# Altium schematic sheet MIXR Input System - Power&Control.SchDoc
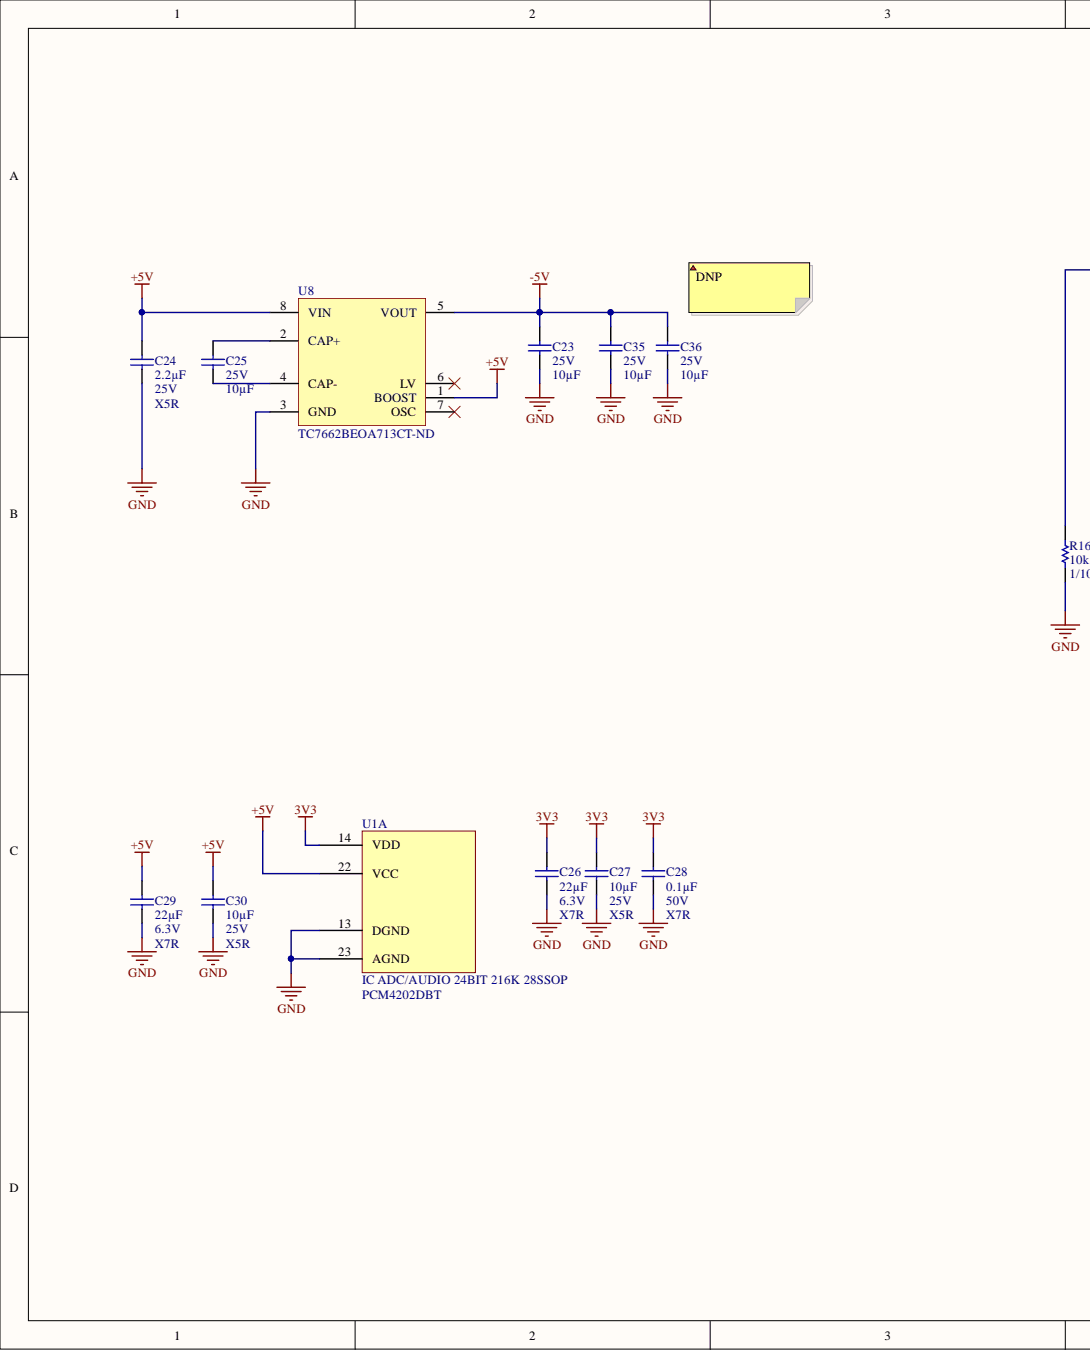
[diagram p0: MIXR Input System - Power&Control.SchDoc, left]
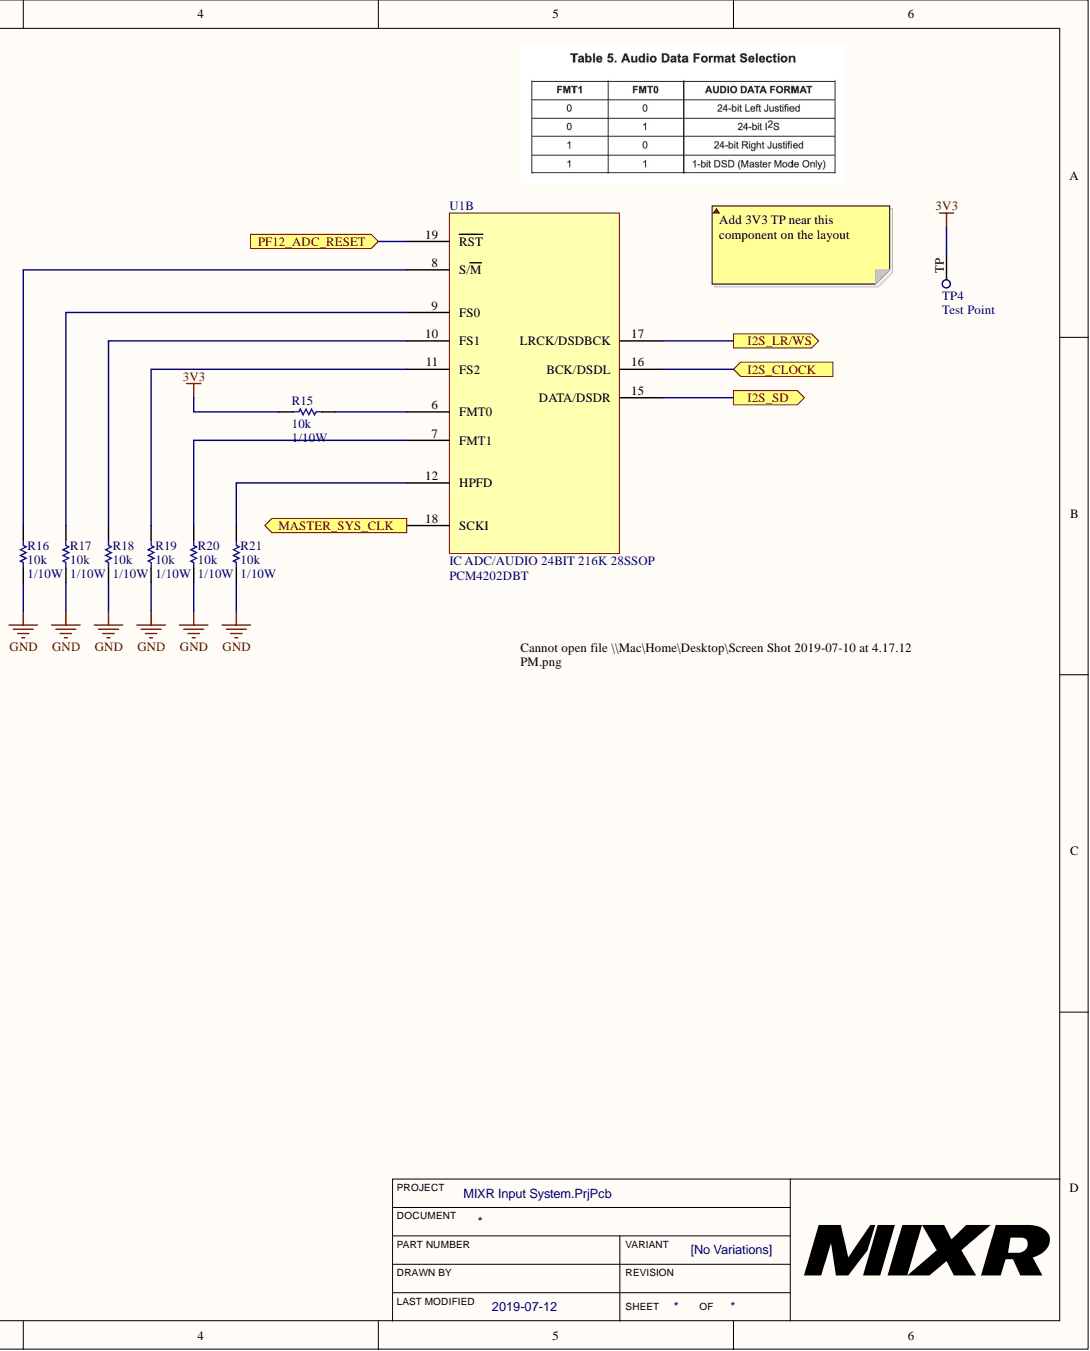
[diagram p1: MIXR Input System - Power&Control.SchDoc, right]

## Parts
C23  Capacitance: 10µF  Voltage - Rated: 25V  [CAP, 0805]  (hidden comment: CAP CER 10uF 25V 10% X5R 0805)
C24  Capacitance: 2.2µF  Temperature Coefficient: X5R  Voltage: 25V  [CAP, 0603]  (hidden comment: CAP CER 2.2UF 25V 10% X5R 0603)
C25  Capacitance: 10µF  Voltage - Rated: 25V  [CAP, 0805]  (hidden comment: CAP CER 10uF 25V 10% X5R 0805)
C26  Capacitance: 22µF  Temperature Coefficient: X7R  Voltage: 6.3V  [CAP, 1206]  (hidden comment: CAP CER 22UF 6.3V ±10% X7R 1206)
C27  Capacitance: 10µF  Temperature Coefficient: X5R  Voltage: 25V  [CAP, 0603]  (hidden comment: CAP CER 10uF 25V 20% X5R 0603)
C28  Capacitance: 0.1µF  Temperature Coefficient: X7R  Voltage: 50V  [CAP, 0603]  (hidden comment: CAP CER 0.1UF 50V 10% X7R 0603)
C29  Capacitance: 22µF  Temperature Coefficient: X7R  Voltage: 6.3V  [CAP, 1206]  (hidden comment: CAP CER 22UF 6.3V ±10% X7R 1206)
C30  Capacitance: 10µF  Temperature Coefficient: X5R  Voltage: 25V  [CAP, 0603]  (hidden comment: CAP CER 10uF 25V 20% X5R 0603)
C35  Capacitance: 10µF  Voltage - Rated: 25V  [CAP, 0805]  (hidden comment: CAP CER 10uF 25V 10% X5R 0805)
C36  Capacitance: 10µF  Voltage - Rated: 25V  [CAP, 0805]  (hidden comment: CAP CER 10uF 25V 10% X5R 0805)
R15  Power: 1/10W  Resistance: 10k  [RES, 0603]  (hidden comment: RES 10K OHM 1% 1/10W 0603)
R16  Power: 1/10W  Resistance: 10k  [RES, 0603]  (hidden comment: RES 10K OHM 1% 1/10W 0603)
R17  Power: 1/10W  Resistance: 10k  [RES, 0603]  (hidden comment: RES 10K OHM 1% 1/10W 0603)
R18  Power: 1/10W  Resistance: 10k  [RES, 0603]  (hidden comment: RES 10K OHM 1% 1/10W 0603)
R19  Power: 1/10W  Resistance: 10k  [RES, 0603]  (hidden comment: RES 10K OHM 1% 1/10W 0603)
R20  Power: 1/10W  Resistance: 10k  [RES, 0603]  (hidden comment: RES 10K OHM 1% 1/10W 0603)
R21  Power: 1/10W  Resistance: 10k  [RES, 0603]  (hidden comment: RES 10K OHM 1% 1/10W 0603)
TP4  Test Point  [Test Point]  (no BOM)
U1  IC ADC/AUDIO 24BIT 216K 28SSOP  Manufacturer Part Number: PCM4202DBT  [IC ADC 24 BIT AUDIO 216 KHZ SSOP-28]  (parts A,B)
U8  Supplier Part Number 1: TC7662BEOA713CT-ND  [SOIC8]  (hidden comment: IC REG SWTCHD CAP INV 20MA 8SOIC)

## Ports
I2S_CLOCK, I2S_LR/WS, I2S_SD, MASTER_SYS_CLK, PF12_ADC_RESET

## Nets
3V3: C26.1 C27.1 C28.1 R15.1 TP4.TP U1.14(VDD)
+5V: C24.2 C29.1 C30.1 U1.22(VCC) U8.1(BOOST) U8.8(VIN)
-5V: C23.1 C35.1 C36.1 U8.5(VOUT)
GND: C23.2 C24.1 C26.2 C27.2 C28.2 C29.2 C30.2 C35.2 C36.2 R16.2 R17.2 R18.2 R19.2 R20.2 R21.2 U1.13(DGND) U1.23(AGND) U8.3(GND)
I2S_CLOCK: U1.16(BCK/DSDL) ; port I2S_CLOCK
I2S_LR/WS: U1.17(LRCK/DSDBCK) ; port I2S_LR/WS
I2S_SD: U1.15(DATA/DSDR) ; port I2S_SD
MASTER_SYS_CLK: U1.18(SCKI) ; port MASTER_SYS_CLK
PF12_ADC_RESET: U1.19(RST) ; port PF12_ADC_RESET
NetC25_1: C25.1 U8.2(CAP+)
NetC25_2: C25.2 U8.4(CAP-)
NetR15_2: R15.2 U1.6(FMT0)
NetR16_1: R16.1 U1.8(S/M)
NetR17_1: R17.1 U1.9(FS0)
NetR18_1: R18.1 U1.10(FS1)
NetR19_1: R19.1 U1.11(FS2)
NetR20_1: R20.1 U1.7(FMT1)
NetR21_1: R21.1 U1.12(HPFD)

Not connected: U8 6(LV) 7(OSC)

## Library data of the parts
C23 C25 C35 C36 = CAP CER 10uF 25V 10% X5R 0805: 10µF ±10% 25V Ceramic Capacitor X5R 0805 (2012 Metric); Manufacturer: Murata Electronics North America; Manufacturer Part Number: GRM21BR61E106KA73L; Applications: General Purpose; Category: Capacitors; Component Kind: Standard; ComponentLink1Description: http://search.murata.co.jp/Ceramy/image/img/A01X/G101/ENG/GRM21BR61E106KA73-01.pdf; ComponentLink1URL: http://search.murata.co.jp/Ceramy/image/img/A01X/G101/ENG/GRM21BR61E106KA73-01.pdf; Features: -; Height - Seated (Max): -; Lead Spacing: -; Lead Style: -; Mounting Type: Surface Mount, MLCC; Operating Temperature: -55°C ~ 85°C; Package / Case: 0805 (2012 Metric); Packaging: Cut Tape (CT); Part Status: Active; Pricing 1: 1=0.3, 10=0.214, 100=0.1008, 500=0.07068, 1000=0.05851 (CAD); Ratings: -; RoHS: RoHS Compliant; Size / Dimension: 0.079" L x 0.049" W (2.00mm x 1.25mm); Stock 1: 1695157; Supplier 1: Digi-Key; Supplier Part Number 1: 490-5523-1-ND; Temperature Coefficient: X5R; Thickness (Max): 0.053" (1.35mm); Tolerance: ±10%; Library Name: Schematic Diagrams.SchLib
C24 = CAP CER 2.2UF 25V 10% X5R 0603: 2.2µF ±10% 25V Ceramic Capacitor X5R 0603 (1608 Metric); Manufacturer 1: Murata Electronics North America; Manufacturer Part Number 1: GRM188R61E225KA12D; Component Kind: Standard; ComponentLink1Description: http://www.murata.com/~/media/webrenewal/support/library/catalog/products/capacitor/mlcc/c02e.ashx; ComponentLink1URL: http://www.murata.com/~/media/webrenewal/support/library/catalog/products/capacitor/mlcc/c02e.ashx; Height - Seated (Max): -; Lead Spacing: -; Lead Style: -; Mounting Type: Surface Mount, MLCC; Operating Temperature: -55°C ~ 85°C; Package / Case: 0603 (1608 Metric); Packaging: Cut Tape (CT); Part Status: Active; Ratings: -; Size / Dimension: 0.063" L x 0.031" W (1.60mm x 0.80mm); Supplier 1: Digi-Key; Supplier Part Number 1: 490-10731-1-ND; Thickness (Max): 0.035" (0.90mm); Tolerance: ±10%; Library Name: Schematic Diagrams.SchLib
C26 C29 = CAP CER 22UF 6.3V ±10% X7R 1206: 22µF ±10% 6.3V Ceramic Capacitor X7R 1206 (3216 Metric); Manufacturer 1: Murata Electronics North America; Manufacturer Part Number 1: GRM31CR70J226KE19L; Component Kind: Standard; ComponentLink1Description: http://www.murata.com/~/media/webrenewal/support/library/catalog/products/capacitor/mlcc/c02e.ashx; ComponentLink1URL: http://www.murata.com/~/media/webrenewal/support/library/catalog/products/capacitor/mlcc/c02e.ashx; Height - Seated (Max): -; Lead Spacing: -; Lead Style: -; Mounting Type: Surface Mount, MLCC; Operating Temperature: -55°C ~ 125°C; Package / Case: 1206 (3216 Metric); Packaging: Cut Tape (CT); Part Status: Active; Ratings: -; Size / Dimension: 0.126" L x 0.063" W (3.20mm x 1.60mm); Supplier 1: Digi-Key; Supplier Part Number 1: 490-6515-1-ND; Thickness (Max): 0.071" (1.80mm); Tolerance: ±10%
C27 C30 = CAP CER 10uF 25V 20% X5R 0603: 10µF ±20% 25V Ceramic Capacitor X5R 0603 (1608 Metric); Manufacturer 1: Murata Electronics North America; Manufacturer Part Number 1: GRM188R61E106MA73D; Component Kind: Standard; ComponentLink1Description: http://www.murata.com/~/media/webrenewal/support/library/catalog/products/capacitor/mlcc/c02e.ashx; ComponentLink1URL: http://www.murata.com/~/media/webrenewal/support/library/catalog/products/capacitor/mlcc/c02e.ashx; Height - Seated (Max): -; Lead Spacing: -; Lead Style: -; Mounting Type: Surface Mount, MLCC; Operating Temperature: -55°C ~ 85°C; Package / Case: 0603 (1608 Metric); Packaging: Cut Tape (CT); Part Status: Active; Ratings: -; Size / Dimension: 0.063" L x 0.031" W (1.60mm x 0.80mm); Supplier 1: Digi-Key; Supplier Part Number 1: 490-7202-1-ND; Thickness (Max): 0.031" (0.80mm); Tolerance: ±20%; Library Name: Schematic Diagrams.SchLib
C28 = CAP CER 0.1UF 50V 10% X7R 0603: 0.10µF ±10% 50V Ceramic Capacitor X7R 0603 (1608 Metric); Manufacturer 1: AVX Corporation; Manufacturer Part Number 1: 06035C104KAT2A; Component Kind: Standard; ComponentLink1Description: http://datasheets.avx.com/X7RDielectric.pdf; ComponentLink1URL: http://datasheets.avx.com/X7RDielectric.pdf; Height - Seated (Max): -; Lead Spacing: -; Lead Style: -; Mounting Type: Surface Mount, MLCC; Operating Temperature: -55°C ~ 125°C; Package / Case: 0603 (1608 Metric); Packaging: Cut Tape (CT); Part Status: Active; Ratings: -; Size / Dimension: 0.063" L x 0.032" W (1.60mm x 0.81mm); Supplier 1: Digi-Key; Supplier Part Number 1: 478-5052-1-ND; Thickness (Max): 0.035" (0.90mm); Tolerance: ±10%; Library Name: Schematic Diagrams.SchLib
R15-R21 = RES 10K OHM 1% 1/10W 0603: RES SMD 10K OHM 1% 1/10W 0603; Manufacturer 1: Yageo; Manufacturer Part Number 1: RC0603FR-0710KL; Temperature Coefficient: ±100ppm/°C; Component Kind: Standard; ComponentLink1Description: http://www.yageo.com/documents/recent/PYu-RC_Group_51_RoHS_L_7.pdf; ComponentLink1URL: http://www.yageo.com/documents/recent/PYu-RC_Group_51_RoHS_L_7.pdf; Composition: Thick Film; Failure Rate: -; Height - Seated (Max): 0.022" (0.55mm); Operating Temperature: -55°C ~ 155°C; Package / Case: 0603 (1608 Metric); Packaging: Cut Tape (CT); Part Status: Active; Size / Dimension: 0.063" L x 0.031" W (1.60mm x 0.80mm); Supplier 1: Digi-Key; Supplier Device Package: 0603; Supplier Part Number 1: 311-10.0KHRCT-ND; Tolerance: ±1%
U1 = IC ADC 24 BIT AUDIO 216KHZ SSOP-28: ADC, Audio 24 bit 216k DSP, PCM 28-SSOP; Manufacturer: Texas Instruments; Conversion Rate: 216KS/s; Interface: Serial; Max Power Dissipation: 408mW; Max Supply Voltage: 5.25V; Min Supply Voltage: 4.75V; Nominal Supply Current: 55mA; Number of A/D Converters: 2; Number of Analog Inputs: 1; Number of Bits: 24; Number of DAC Channels: 0; Operating Supply Voltage: 5V; Polarity: Bipolar; Power Consumption: 338mW; Resolution: 24b; Supply Type: Analog; Case/Package: SSOP; Component Kind: Standard; ComponentLink1Description: https://datasheet.ciiva.com/25988/sbas290b-25988737.pdf?src-supplier=Avnet+Europe; ComponentLink1URL: https://datasheet.ciiva.com/25988/sbas290b-25988737.pdf?src-supplier=Avnet+Europe; ComponentLink2Description: https://datasheet.ciiva.com/41460/001186374-da-01-en-ic-adc-stereo-24b-pcm4202dbt-ssop-28-tid-41460403.pdf?src-supplier=Conrad; ComponentLink2URL: https://datasheet.ciiva.com/41460/001186374-da-01-en-ic-adc-stereo-24b-pcm4202dbt-ssop-28-tid-41460403.pdf?src-supplier=Conrad; ComponentLink3Description: https://datasheet.ciiva.com/26800/pcm4202dbt-26800794.pdf?src-supplier=IIIC; ComponentLink3URL: https://datasheet.ciiva.com/26800/pcm4202dbt-26800794.pdf?src-supplier=IIIC; ComponentLink4Description: https://datasheet.ciiva.com/29896/sbas290b-29896124.pdf?src-supplier=Avnet; ComponentLink4URL: https://datasheet.ciiva.com/29896/sbas290b-29896124.pdf?src-supplier=Avnet; ComponentLink5Description: https://datasheet.ciiva.com/1307/pcm4202-1307121.pdf?src-supplier=Digi-Key; ComponentLink5URL: https://datasheet.ciiva.com/1307/pcm4202-1307121.pdf?src-supplier=Digi-Key; ComponentLink6Description: https://datasheet.ciiva.com/1307/pcm4202-1307122.pdf?src-supplier=Digi-Key; ComponentLink6URL: https://datasheet.ciiva.com/1307/pcm4202-1307122.pdf?src-supplier=Digi-Key; ComponentLink7Description: https://datasheet.ciiva.com/1307/pcm4202-1307123.pdf?src-supplier=Digi-Key; ComponentLink7URL: https://datasheet.ciiva.com/1307/pcm4202-1307123.pdf?src-supplier=Digi-Key; ComponentLink8Description: https://datasheet.ciiva.com/15147/getdatasheetpartid-171631-15147899.pdf?src-supplier=Verical; ComponentLink8URL: https://datasheet.ciiva.com/15147/getdatasheetpartid-171631-15147899.pdf?src-supplier=Verical; Height: 1.95mm; Lead Free: Lead Free; Length: 10.2mm; Max Operating Temperature: 70°C; Min Operating Temperature: -10°C; Mount: Surface Mount; Number of ADC Channels: 2; Number of Converters: 2; Number of Elements: 2; Number of Pins: 28; Package Quantity: 250; Packaging: Tape and Reel; Power Dissipation: 408mW; Pricing 1: 1 = 9.85000, 10 = 8.89800, 100 = 7.36660 (USD); Radiation Hardening: No; REACH SVHC: No SVHC; RoHS: Yes; Sampling Rate: 216KS/s; Stock 1: 5943; Supplier 1: Digi-Key; Supplier Part Number 1: 296-17438-1-ND; Weight: 0.008529oz; Width: 5.3mm; Library Name: Schematic Diagrams.SchLib
U8 = IC REG SWTCHD CAP INV 20MA 8SOIC: Charge Pump Switching Regulator IC Positive or Negative Fixed -Vin, 2Vin 1 Output 20mA 8-SOIC (0.154", 3.90mm Width); Manufacturer: Microchip; Manufacturer Part Number: TC7662BEOA713; Logic Function: Inverting; Max Input Voltage: 15V; Max Output Voltage: -15V; Min Supply Voltage: 1.5V; Number of Outputs: 1; Output Power: 470mW; Switching Frequency: 35kHz; Synchronous Rectifier: No; Case/Package: SOIC; Component Kind: Standard; ComponentLink1Description: https://datasheet.ciiva.com/2024/21469a-2024368.pdf; ComponentLink1URL: https://datasheet.ciiva.com/2024/21469a-2024368.pdf; ComponentLink2Description: https://datasheet.ciiva.com/2024/filehandler-aspxddocname-en026002-2024369.pdf; ComponentLink2URL: https://datasheet.ciiva.com/2024/filehandler-aspxddocname-en026002-2024369.pdf; ComponentLink3Description: https://datasheet.ciiva.com/16295/filehandler-aspxddocname-en011347-16295442.pdf; ComponentLink3URL: https://datasheet.ciiva.com/16295/filehandler-aspxddocname-en011347-16295442.pdf; ComponentLink4Description: https://datasheet.ciiva.com/3216/cn-21469a-3216331.pdf; ComponentLink4URL: https://datasheet.ciiva.com/3216/cn-21469a-3216331.pdf; ComponentLink5Description: https://datasheet.ciiva.com/18553/filehandler-aspxddocname-en026002-18553038.pdf; ComponentLink5URL: https://datasheet.ciiva.com/18553/filehandler-aspxddocname-en026002-18553038.pdf; ComponentLink6Description: https://datasheet.ciiva.com/4875/21469a-4875151.pdf; ComponentLink6URL: https://datasheet.ciiva.com/4875/21469a-4875151.pdf; Height: 1.5mm; Lead Free: Lead Free; Length: 5mm; Max Operating Temperature: 85°C; Max Output Current: 20mA; Max Supply Voltage: 15V; Min Operating Temperature: -40°C; Mount: Surface Mount; Number of Pins: 8; Output Current: 20mA; Output Voltage: -15V; Package Quantity: 1; Packaging: Tape and Reel; Pricing 1: 1=2.29, 25=1.9056, 100=1.7304 (USD); Radiation Hardening: No; Stock 1: 59; Supplier 1: Digi-Key; Topology: Charge Pump; Weight: 0.006596oz; Width: 3.99mm; Library Name: Schematic Diagrams.SchLib
TP4 = Test Point: Library Name: Schematic Diagrams.SchLib
